# Altium schematic sheet 340-660-005.SchDoc(340-660-005)
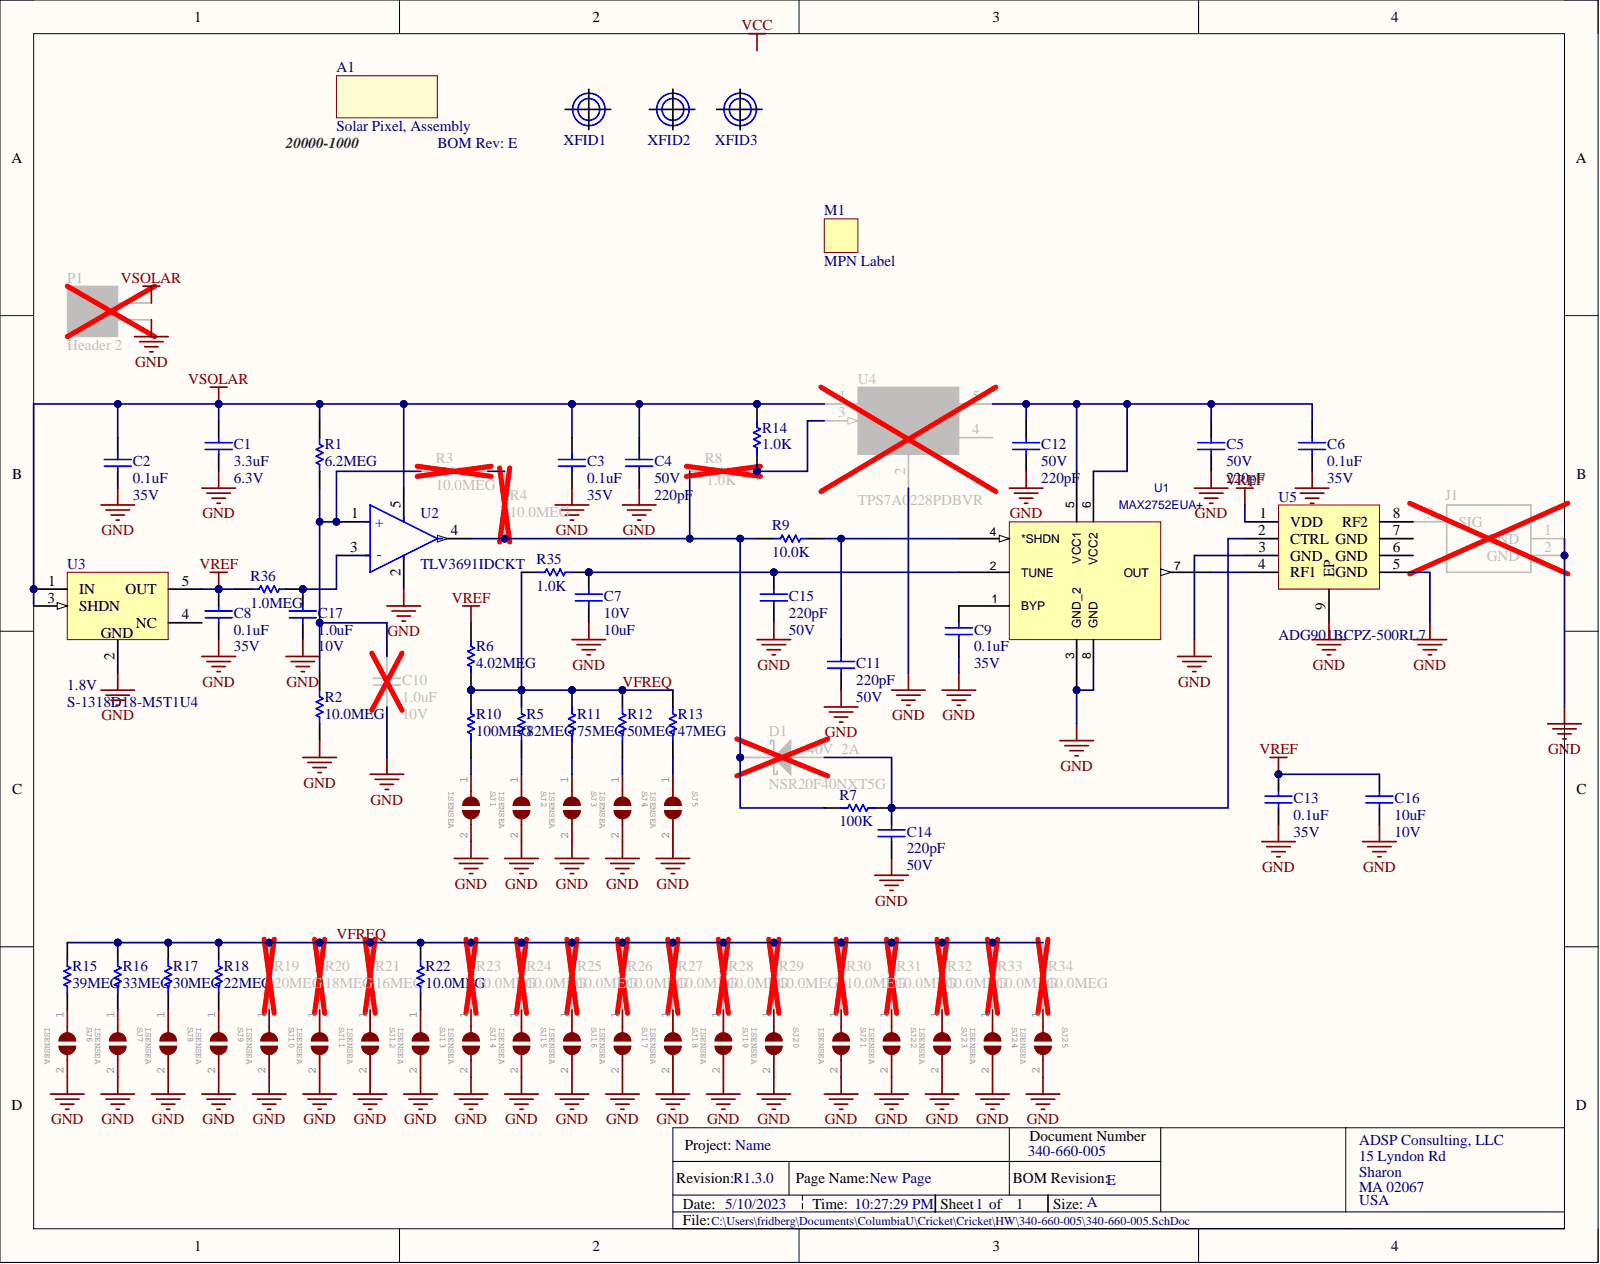
[diagram p0: 340-660-005.SchDoc]

## Parts
A1  Solar Pixel, Assembly  ADSP_PN: 20000-1000  (mechanical)
C1  value 3.3uF  Voltage: 6.3V  [0402CAP]  (hidden comment: C1005X5R0J335K050BC)
C2  value 0.1uF  Voltage: 35V  [0402CAP]  (hidden comment: GMK105BJ104KV-F)
C3  value 0.1uF  Voltage: 35V  [0402CAP]  (hidden comment: GMK105BJ104KV-F)
C4  value 220pF  Voltage: 50V  [0402CAP]  (hidden comment: ECJ-0EC1H221J)
C5  value 220pF  Voltage: 50V  [0402CAP]  (hidden comment: ECJ-0EC1H221J)
C6  value 0.1uF  Voltage: 35V  [0402CAP]  (hidden comment: GMK105BJ104KV-F)
C7  value 10uF  Voltage: 10V  [0402CAP]  (hidden comment: CL05A106MP5NUNC)
C8  value 0.1uF  Voltage: 35V  [0402CAP]  (hidden comment: GMK105BJ104KV-F)
C9  value 0.1uF  Voltage: 35V  [0402CAP]  (hidden comment: GMK105BJ104KV-F)
C10  value 1.0uF  Voltage: 10V  [0402CAP]  (hidden comment: 0402ZD105KAT2A)
C11  value 220pF  Voltage: 50V  [0402CAP]  (hidden comment: ECJ-0EC1H221J)
C12  value 220pF  Voltage: 50V  [0402CAP]  (hidden comment: ECJ-0EC1H221J)
C13  value 0.1uF  Voltage: 35V  [0402CAP]  (hidden comment: GMK105BJ104KV-F)
C14  value 220pF  Voltage: 50V  [0402CAP]  (hidden comment: ECJ-0EC1H221J)
C15  value 220pF  Voltage: 50V  [0402CAP]  (hidden comment: ECJ-0EC1H221J)
C16  value 10uF  Voltage: 10V  [0402CAP]  (hidden comment: CL05A106MP5NUNC)
C17  value 1.0uF  Voltage: 10V  [0402CAP]  (hidden comment: 0402ZD105KAT2A)
D1  NSR20F40NXT5G  Current: 2A  Voltage: 40V  [DIODE_2-DSN_ON]
J1  (no value)  [CONN_HRS-U.FL-R-SMT_V]
M1  MPN Label  [LABEL_7x7mm]  (no BOM)
P1  Header 2  [HDR1X2]
R1  6.2MEG  [0402RES]
R2  value 10.0MEG  [0402RES]  (hidden comment: CRCW040210M0FKED)
R3  value 10.0MEG  [0402RES]  (hidden comment: CRCW040210M0FKED)
R4  value 10.0MEG  [0402RES]  (hidden comment: CRCW040210M0FKED)
R5  value 82MEG  [0402RES]  (hidden comment: HMC0402JT82M0)
R6  4.02MEG  [0402RES]
R7  value 100K  [0402RES]  (hidden comment: RC0402FR-07100KL)
R8  value 1.0K  [0402RES]  (hidden comment: RCS04021K00FKED)
R9  value 10.0K  [0402RES]  (hidden comment: CRCW040210K0FKEDHP)
R10  value 100MEG  [0402RES]  (hidden comment: HMC0402JT100M)
R11  value 75MEG  [0402RES]  (hidden comment: HMC0402JT75M0)
R12  value 50MEG  [0402RES]  (hidden comment: HMC0402JT50M0)
R13  value 47MEG  [0402RES]  (hidden comment: HMC0402JT47M0)
R14  value 1.0K  [0402RES]  (hidden comment: RCS04021K00FKED)
R15  value 39MEG  [0402RES]  (hidden comment: HMC0402JT39M0)
R16  value 33MEG  [0402RES]  (hidden comment: HMC0402JT33M0)
R17  value 30MEG  [0402RES]  (hidden comment: HMC0402JT30M0)
R18  value 22MEG  [0402RES]  (hidden comment: RC0402JR-0722ML)
R19  value 20MEG  [0402RES]
R20  value 18MEG  [0402RES]
R21  value 16MEG  [0402RES]
R22  value 10.0MEG  [0402RES]  (hidden comment: CRCW040210M0FKED)
R23  value 10.0MEG  [0402RES]  (hidden comment: CRCW040210M0FKED)
R24  value 10.0MEG  [0402RES]  (hidden comment: CRCW040210M0FKED)
R25  value 10.0MEG  [0402RES]  (hidden comment: CRCW040210M0FKED)
R26  value 10.0MEG  [0402RES]  (hidden comment: CRCW040210M0FKED)
R27  value 10.0MEG  [0402RES]  (hidden comment: CRCW040210M0FKED)
R28  value 10.0MEG  [0402RES]  (hidden comment: CRCW040210M0FKED)
R29  value 10.0MEG  [0402RES]  (hidden comment: CRCW040210M0FKED)
R30  value 10.0MEG  [0402RES]  (hidden comment: CRCW040210M0FKED)
R31  value 10.0MEG  [0402RES]  (hidden comment: CRCW040210M0FKED)
R32  value 10.0MEG  [0402RES]  (hidden comment: CRCW040210M0FKED)
R33  value 10.0MEG  [0402RES]  (hidden comment: CRCW040210M0FKED)
R34  value 10.0MEG  [0402RES]  (hidden comment: CRCW040210M0FKED)
R35  value 1.0K  [0402RES]  (hidden comment: RCS04021K00FKED)
R36  value 1.0MEG  [0402RES]  (hidden comment: RC0402FR-071ML)
SJ1  ISENSEA  [SOLDERJUMPER_ARROW_NOPASTE]  (no BOM)
SJ2  ISENSEA  [SOLDERJUMPER_ARROW_NOPASTE]  (no BOM)
SJ3  ISENSEA  [SOLDERJUMPER_ARROW_NOPASTE]  (no BOM)
SJ4  ISENSEA  [SOLDERJUMPER_ARROW_NOPASTE]  (no BOM)
SJ5  ISENSEA  [SOLDERJUMPER_ARROW_NOPASTE]  (no BOM)
SJ6  ISENSEA  [SOLDERJUMPER_ARROW_NOPASTE]  (no BOM)
SJ7  ISENSEA  [SOLDERJUMPER_ARROW_NOPASTE]  (no BOM)
SJ8  ISENSEA  [SOLDERJUMPER_ARROW_NOPASTE]  (no BOM)
SJ9  ISENSEA  [SOLDERJUMPER_ARROW_NOPASTE]  (no BOM)
SJ10  ISENSEA  [SOLDERJUMPER_ARROW_NOPASTE]  (no BOM)
SJ11  ISENSEA  [SOLDERJUMPER_ARROW_NOPASTE]  (no BOM)
SJ12  ISENSEA  [SOLDERJUMPER_ARROW_NOPASTE]  (no BOM)
SJ13  ISENSEA  [SOLDERJUMPER_ARROW_NOPASTE]  (no BOM)
SJ14  ISENSEA  [SOLDERJUMPER_ARROW_NOPASTE]  (no BOM)
SJ15  ISENSEA  [SOLDERJUMPER_ARROW_NOPASTE]  (no BOM)
SJ16  ISENSEA  [SOLDERJUMPER_ARROW_NOPASTE]  (no BOM)
SJ17  ISENSEA  [SOLDERJUMPER_ARROW_NOPASTE]  (no BOM)
SJ18  ISENSEA  [SOLDERJUMPER_ARROW_NOPASTE]  (no BOM)
SJ19  ISENSEA  [SOLDERJUMPER_ARROW_NOPASTE]  (no BOM)
SJ20  ISENSEA  [SOLDERJUMPER_ARROW_NOPASTE]  (no BOM)
SJ21  ISENSEA  [SOLDERJUMPER_ARROW_NOPASTE]  (no BOM)
SJ22  ISENSEA  [SOLDERJUMPER_ARROW_NOPASTE]  (no BOM)
SJ23  ISENSEA  [SOLDERJUMPER_ARROW_NOPASTE]  (no BOM)
SJ24  ISENSEA  [SOLDERJUMPER_ARROW_NOPASTE]  (no BOM)
SJ25  ISENSEA  [SOLDERJUMPER_ARROW_NOPASTE]  (no BOM)
U1  MAX2752EUA+  [21-0036K_MXM]
U2  TLV3691IDCKT  [SC70/5]
U3  S-1318D18-M5T1U4  Vout: 1.8V  [SOT-23/5]
U4  TPS7A0228PDBVR  [SOT-23/5]
U5  ADG901BCPZ-500RL7  [SON50P300X300X85-9N-D]
XFID1  (no value)  [FID_TOP_BOT_39]
XFID2  (no value)  [FID_TOP_BOT_39]
XFID3  (no value)  [FID_TOP_BOT_39]

## Nets
GND: C1.1 C2.1 C3.1 C4.1 C5.1 C6.1 C7.1 C8.1 C9.1 C10.1 C11.1 C12.1 C13.1 C14.1 C15.1 C16.1 C17.1 J1.1 J1.2 P1.1 R2.1 SJ1.2 SJ2.2 SJ3.2 SJ4.2 SJ5.2 SJ6.2 SJ7.2 SJ8.2 SJ9.2 SJ10.2 SJ11.2 SJ12.2 SJ13.2 SJ14.2 SJ15.2 SJ16.2 SJ17.2 SJ18.2 SJ19.2 SJ20.2 SJ21.2 SJ22.2 SJ23.2 SJ24.2 SJ25.2 U1.3(GND_2) U1.8(GND) U2.2 U3.2 U4.2 U5.3(GND) U5.5(GND) U5.9(EP)
VREF: C8.2 C13.2 C16.2 R6.2 R36.2 U3.5(OUT) U5.1(VDD)
VSOLAR: C1.2 C2.2 C3.2 C4.2 P1.2 R1.2 R14.1 U2.5 U3.1(IN) U3.3(SHDN) U4.1(IN)
VFREQ: R5.2 R6.1 R10.2 R11.2 R12.2 R13.2 R15.2 R16.2 R17.2 R18.2 R19.2 R20.2 R21.2 R22.2 R23.2 R24.2 R25.2 R26.2 R27.2 R28.2 R29.2 R30.2 R31.2 R32.2 R33.2 R34.2 R35.2
NetC5_2: C5.2 C6.2 C12.2 U1.5(VCC1) U1.6(VCC2) U4.5(OUT)
NetC7_2: C7.2 C15.2 R35.1 U1.2(TUNE)
NetC9_2: C9.2 U1.1(BYP)
NetC10_2: C10.2 R1.1 R2.2 R3.2 U2.1(+)
NetC11_2: C11.2 R9.1 U1.4(*SHDN)
NetC14_2: C14.2 D1.A R7.1 U5.2(CTRL)
NetC17_2: C17.2 R36.1 U2.3(-)
NetD1_C: D1.C R4.1 R7.2 R8.2 R9.2 U2.4
NetJ1_3: J1.3 U5.8(RF2)
NetR3_1: R3.1 R4.2
NetR5_1: R5.1 SJ2.1
NetR8_1: R8.1 R14.2 U4.3(EN)
NetR10_1: R10.1 SJ1.1
NetR11_1: R11.1 SJ3.1
NetR12_1: R12.1 SJ4.1
NetR13_1: R13.1 SJ5.1
NetR15_1: R15.1 SJ6.1
NetR16_1: R16.1 SJ7.1
NetR17_1: R17.1 SJ8.1
NetR18_1: R18.1 SJ9.1
NetR19_1: R19.1 SJ10.1
NetR20_1: R20.1 SJ11.1
NetR21_1: R21.1 SJ12.1
NetR22_1: R22.1 SJ13.1
NetR23_1: R23.1 SJ14.1
NetR24_1: R24.1 SJ15.1
NetR25_1: R25.1 SJ16.1
NetR26_1: R26.1 SJ17.1
NetR27_1: R27.1 SJ18.1
NetR28_1: R28.1 SJ19.1
NetR29_1: R29.1 SJ20.1
NetR30_1: R30.1 SJ21.1
NetR31_1: R31.1 SJ22.1
NetR32_1: R32.1 SJ23.1
NetR33_1: R33.1 SJ24.1
NetR34_1: R34.1 SJ25.1
NetU1_7: U1.7(OUT) U5.4(RF1)
VCC:

Not connected: U3 4(NC); U4 4(NC); U5 6(GND) 7(GND)

## Library data of the parts
A1 = PCB_Assembly: BOM Rev: E; DocNum: 340-660-005; Description: Solar Pixel, Assembly; Library Name: AltiumSchLib.SchLib; Part: 20000-1000; Rev: R1.3.0; Type: ASSEMBLY
C1 = CAP_0402_10uF_X5R_10V: CAP CER 10UF 10V X5R 0402; ADSP_PN: 01020-167; Mfg: TDK; Type: CAPACITOR; Component Kind: Standard; ComponentLink1Description: Spec; ComponentLink2Description: Datasheet; Library Name: AltiumSchLib.SchLib; Mfg_PN: C1005X5R0J335K050BC; Part: C1005X5R0J335K050BC; Signal Integrity: C0402; Simulation: CAP; Publisher: ADSP Consulting, LLC
C2 C3 C6 C8 C9 C13 = CAP_0402_0.1uF_X5R_35V: CAP CER .10UF 35V X5R 0402; ADSP_PN: 01010-054; Mfg: Taiyo Yuden; Type: CAPACITOR; Component Kind: Standard; ComponentLink1Description: Manufacturer Link; ComponentLink1URL: http://www.yuden.com; ComponentLink2Description: Datasheet; ComponentLink2URL: http://www.yuden.co.jp/ut/product/pdf/dlmk_e.pdf; Library Name: AltiumSchLib.SchLib; Mfg_PN: GMK105BJ104KV-F; Part: GMK105BJ104KV-F; Signal Integrity: C0402; Simulation: CAP; Supplier 1: Digi-Key; Supplier 2: Digi-Key; Supplier Part Number 1: 587-1994-1-ND; Supplier Part Number 2: 587-1994-6-ND; Publisher: ADSP Consulting, LLC
C4 C5 C11 C12 C14 C15 = CAP_0402_220pF_NP0_50V: CAP 220PF 50V CERAMIC 0402 SMD; ADSP_PN: 01010-028; Mfg: Panasonic; Type: CAPACITOR; Component Kind: Standard; ComponentLink1Description: Manufacturer Link; ComponentLink1URL: http://industrial.panasonic.com; ComponentLink2Description: Datasheet; ComponentLink2URL: http://industrial.panasonic.com/www-data/pdf/ABJ0000/ABJ0000CE1.pdf; Library Name: AltiumSchLib.SchLib; Mfg_PN: ECJ-0EC1H221J; Part: ECJ-0EC1H221J; Signal Integrity: C0402; Simulation: CAP; Supplier 1: Digi-Key; Supplier 2: Digi-Key; Supplier Part Number 1: PCC221CQCT-ND; Supplier Part Number 2: PCC221CQTR-ND; Publisher: ADSP Consulting, LLC
C7 C16 = CAP_0402_10uF_X5R_10V: CAP CER 10UF 10V X5R 0402; ADSP_PN: 01020-053; Mfg: Samsung; Type: CAPACITOR; Component Kind: Standard; ComponentLink1Description: Spec; ComponentLink1URL: http://www.samsungsem.com/kr/support/product-search/mlcc/CL05A106MP5NUNC.jsp; ComponentLink2Description: Datasheet; ComponentLink2URL: https://media.digikey.com/pdf/Data%20Sheets/Samsung%20PDFs/CL_Series_MLCC_ds.pdf; HelpURL: https://www.digikey.com/product-detail/en/samsung-electro-mechanics/CL05A106MP5NUNC/1276-1450-1-ND/3889536; Library Name: AltiumSchLib.SchLib; Mfg_PN: CL05A106MP5NUNC; Part: CL05A106MP5NUNC; Signal Integrity: C0402; Simulation: CAP; Supplier 1: Newark; Supplier 2: Arrow; Supplier Part Number 1: 82AC9190; Supplier Part Number 2: CL05A106MP5NUNC; Publisher: ADSP Consulting, LLC
C10 C17 = CAP_0402_1uF_X5R_10V: CAP CERAMIC 1UF 10V X5R 0402; ADSP_PN: 01020-057; Mfg: AVX; Type: CAPACITOR; Component Kind: Standard; ComponentLink1Description: Manufacturer Link; ComponentLink1URL: http://www.murata.co.jp; ComponentLink2Description: Datasheet; ComponentLink2URL: http://search.murata.co.jp/Ceramy/image/img/PDF/ENG/GRM155R61A105ME15.pdf; Library Name: AltiumSchLib.SchLib; Mfg_PN: 0402ZD105KAT2A; Part: 0402ZD105KAT2A; Signal Integrity: C0402; Simulation: CAP; Supplier 1: Octopart; Supplier 2: Octopart; Supplier 3: Arrow; Supplier Part Number 1: Digi-Key - 490-5409-1-ND; Supplier Part Number 2: Mouser - 81-GRM155R61A105ME5D; Supplier Part Number 3: 0uyQcnB1MzVTno5V05qqdMHdKEPD0ulzJqQipA1FZ_7L41RCS0E1VAx706hUl3Jd; Publisher: ADSP Consulting, LLC
D1 = Diode_SHOTTKY_NSR20F40NXT5G: Diode Schottky 40V 2A (DC) Surface Mount 2-DSN (1.6x.80); ADSP_PN: 08100-027; Mfg: ON Semi; Component Kind: Standard; ComponentLink1Description: Manufacturer Link; ComponentLink1URL: https://www.onsemi.com/PowerSolutions/product.do?id=NSR20F40NXT5G; ComponentLink2Description: Datasheet; ComponentLink2URL: https://www.onsemi.com/pub/Collateral/NSR20F40-D.PDF; Library Name: AltiumSchLib.SchLib; Mfg_PN: NSR20F40NXT5G; Part: NSR20F40NXT5G; Supplier 1: Digi-Key; Supplier 2: Mouser; Supplier Part Number 1: NSR20F40NXT5GOSCT-ND; Supplier Part Number 2: 863-NSR20F40NXT5G; Type: DIODE_SHOTTKY
J1 = CONN_Hirose_U.FL-R-SMT(10): Ultra Small Surface Mount Coaxial Connector, 50 Ohm, -40 to 90 degC, 3-Pin SMD, RoHS, Tape and Reel; ADSP_PN: 03000-233; Current Rating: 3 A; Mfg: Hirose; Colour: Black; Component Kind: Standard; ComponentLink1Description: Manufacturer URL; ComponentLink1URL: https://www.hirose.com; ComponentLink2Description: Datasheet; ComponentLink2URL: https://www.hirose.com/product/en/download_file/key_name/U.FL/category/Catalog/doc_file_id/31697/?file_category_id=4&item_id=15&is_series=1; Contact Finish: Lead; Contact Material: Bronze; Contact Plating: Tin; Height: 8.13 mm; Lead Pitch: 2.54 mm; Length: 101.19 mm; Library Name: AltiumSchLib.SchLib; Max Operating Temperature: 105degC; Mfg_PN: U.FL-R-SMT(10); Min Operating Temperature: -65degC; Package Quantity: 50; PackageDescription: 3-Pin Surface Mount Device, Body 2.6 x 2.6 mm; Part: U.FL-R-SMT(10); Supplier 1: Digi-Key; Supplier 2: Future Electronics; Supplier Part Number 1: H122041-ND; Supplier Part Number 2: W.FL-R-SMT-1(10); Width: 4.88 mm
M1 = Label_MPN: Serial Number Label Placeholder; Library Name: AltiumSchLib.SchLib; Part: MPN Label
P1 = Header 2: Header, 2-Pin; Component Kind: Standard; LatestRevisionDate: 17-Jul-2002; LatestRevisionNote: Re-released for DXP Platform.; Library Name: Miscellaneous Connectors.IntLib; Signal Integrity: Connector; Publisher: Altium Limited
R1 = Res_0402_6.2MEG_1%: RES 6.2M OHM 1/16W 1% 0402 SMD; ADSP_PN: 00100-134; Mfg: Vishay; Mfg_PN: CRCW04026M20JNED; Component Kind: Standard; ComponentLink1Description: Manufacturer Link; ComponentLink1URL: http://www.vishay.com; ComponentLink2Description: Datasheet; ComponentLink2URL: http://www.vishay.com/docs/20035/dcrcwe3.pdf; Library Name: AltiumSchLib.SchLib; Part: 6.2MEG; Signal Integrity: Res; Simulation: RESISTOR; Supplier 1: Digi-Key; Supplier 2: Mouser; Supplier Part Number 1: 541-6.2MJCT-ND; Supplier Part Number 2: 71-CRCW04026M20JNED; Type: RESISTOR; Value: 6.2MEG; Publisher: ADSP Consulting, LLC
R2-R4 R19 R22-R34 = Res_0402_10MEG_1%: RES 10M OHM 1/16W 1% 0402 SMD; ADSP_PN: 00100-135; Mfg: Vishay; Component Kind: Standard; ComponentLink1Description: Manufacturer Link; ComponentLink1URL: http://www.vishay.com; ComponentLink2Description: Datasheet; ComponentLink2URL: http://www.vishay.com/docs/20035/dcrcwe3.pdf; Library Name: AltiumSchLib.SchLib; Signal Integrity: Res; Simulation: RESISTOR; Type: RESISTOR; Publisher: ADSP Consulting, LLC
  R2-R4 R22-R34: Mfg_PN: CRCW040210M0FKED; Part: CRCW040210M0FKED; Supplier 1: Digi-Key; Supplier 2: Mouser; Supplier Part Number 1: 541-10.0MLDKR-ND; Supplier Part Number 2: 71-CRCW040210M0FKED
R5 = Res_0402_10MEG_1%: RES 10M OHM 1/16W 1% 0402 SMD; ADSP_PN: 00100-228; Mfg: Stackpole; Component Kind: Standard; ComponentLink1Description: Manufacturer Link; ComponentLink2Description: Datasheet; Library Name: AltiumSchLib.SchLib; Mfg_PN: HMC0402JT82M0; Part: HMC0402JT82M0; Signal Integrity: Res; Simulation: RESISTOR; Type: RESISTOR; Publisher: ADSP Consulting, LLC
R6 = Res_0402_4.02MEG_1%: RES 4.02M OHM 1/16W 1% 0402 SMD; ADSP_PN: 00100-132; Mfg: Vishay; Mfg_PN: CRCW04024M02FKED; Component Kind: Standard; ComponentLink1Description: Manufacturer Link; ComponentLink1URL: http://www.vishay.com; ComponentLink2Description: Datasheet; ComponentLink2URL: http://www.vishay.com/docs/20035/dcrcwe3.pdf; Library Name: AltiumSchLib.SchLib; Part: 4.02MEG; Signal Integrity: Res; Simulation: RESISTOR; Supplier 1: Digi-Key; Supplier 2: Mouser; Supplier Part Number 1: 541-4.02MLCT-ND; Supplier Part Number 2: 71-CRCW04024M02FKED; Type: RESISTOR; Value: 4.02MEG; Publisher: ADSP Consulting, LLC
R7 = Res_0402_100K_1%: RES 100K OHM 1/16W 1% 0402 SMD; ADSP_PN: 00100-028; Mfg: Yageo; Component Kind: Standard; ComponentLink1Description: Manufacturer Link; ComponentLink1URL: http://www.yageo.com; ComponentLink2Description: Datasheet; ComponentLink2URL: http://www.yageo.com/pdf/Pu-RC0402_51_PbFree_L_2.pdf; Library Name: AltiumSchLib.SchLib; Mfg_PN: RC0402FR-07100KL; Part: RC0402FR-07100KL; Signal Integrity: Res; Simulation: RESISTOR; Supplier 1: Digi-Key; Supplier 2: Newark; Supplier 3: Digi-Key; Supplier 4: Newark; Supplier Part Number 1: 311-100KLRCT-ND; Supplier Part Number 2: 98K7502; Supplier Part Number 3: 311-100KLRTR-ND; Supplier Part Number 4: 98K7501; Type: RESISTOR; Publisher: ADSP Consulting, LLC
R8 R14 R35 = Res_0402_1.0K_1%: RES SMD 1K OHM 1% 1/5W 0402; ADSP_PN: 00100-158; Mfg: Panasonic; Component Kind: Standard; ComponentLink1Description: Manufacturer Link; ComponentLink1URL: http://industrial.panasonic.com/www-cgi/jvcr13pz.cgi?E+PZ+3+AOA0002+ERJ2RKF1001X+7+WW; ComponentLink2Description: Datasheet; ComponentLink2URL: http://industrial.panasonic.com/www-data/pdf/AOA0000/AOA0000CE2.pdf; Library Name: AltiumSchLib.SchLib; Mfg_PN: RCS04021K00FKED; Part: RCS04021K00FKED; Signal Integrity: Res; Simulation: RESISTOR; Supplier 1: Digi-Key; Supplier Part Number 1: 541-2888-1-ND; Type: RESISTOR; Publisher: ADSP Consulting, LLC
R9 = Res_0402_10.0K_1%: RES 10K OHM 1/10W 1% 0402 SMD; ADSP_PN: 00100-161; Mfg: Vishay; Component Kind: Standard; ComponentLink1Description: Manufacturer Link; ComponentLink1URL: http://www.vishay.com; ComponentLink2Description: Datasheet; ComponentLink2URL: http://www.vishay.com/doc?20035; Library Name: AltiumSchLib.SchLib; Mfg_PN: CRCW040210K0FKEDHP; Part: CRCW040210K0FKEDHP; Signal Integrity: Res; Simulation: RESISTOR; Supplier 1: Avnet; Supplier 2: Mouser; Supplier 3: Digi-Key; Supplier Part Number 1: CRCW040210K0FKEDHP/BKN; Supplier Part Number 2: 71-CRCW040210K0FKEDH; Supplier Part Number 3: 541-10.0KYDKR-ND; Type: RESISTOR; Publisher: ADSP Consulting, LLC
R10 = Res_0402_10MEG_1%: RES 10M OHM 1/16W 1% 0402 SMD; ADSP_PN: 00100-226; Mfg: Stackpole; Component Kind: Standard; ComponentLink1Description: Manufacturer Link; ComponentLink2Description: Datasheet; Library Name: AltiumSchLib.SchLib; Mfg_PN: HMC0402JT100M; Part: HMC0402JT100M; Signal Integrity: Res; Simulation: RESISTOR; Type: RESISTOR; Publisher: ADSP Consulting, LLC
R11 = Res_0402_10MEG_1%: RES 10M OHM 1/16W 1% 0402 SMD; ADSP_PN: 00100-232; Mfg: Stackpole; Component Kind: Standard; ComponentLink1Description: Manufacturer Link; ComponentLink2Description: Datasheet; Library Name: AltiumSchLib.SchLib; Mfg_PN: HMC0402JT75M0; Part: HMC0402JT75M0; Signal Integrity: Res; Simulation: RESISTOR; Type: RESISTOR; Publisher: ADSP Consulting, LLC
R12 = Res_0402_10MEG_1%: RES 10M OHM 1/16W 1% 0402 SMD; ADSP_PN: 00100-231; Mfg: Stackpole; Component Kind: Standard; ComponentLink1Description: Manufacturer Link; ComponentLink1URL: http://www.vishay.com; ComponentLink2Description: Datasheet; ComponentLink2URL: http://www.vishay.com/docs/20035/dcrcwe3.pdf; Library Name: AltiumSchLib.SchLib; Mfg_PN: HMC0402JT50M0; Part: HMC0402JT50M0; Signal Integrity: Res; Simulation: RESISTOR; Type: RESISTOR; Publisher: ADSP Consulting, LLC
R13 = Res_0402_10MEG_1%: RES 10M OHM 1/16W 1% 0402 SMD; ADSP_PN: 00100-227; Mfg: Stackpole; Component Kind: Standard; ComponentLink1Description: Manufacturer Link; ComponentLink2Description: Datasheet; Library Name: AltiumSchLib.SchLib; Mfg_PN: HMC0402JT47M0; Part: HMC0402JT47M0; Signal Integrity: Res; Simulation: RESISTOR; Type: RESISTOR; Publisher: ADSP Consulting, LLC
R15 = Res_0402_10MEG_1%: RES 10M OHM 1/16W 1% 0402 SMD; ADSP_PN: 00100-233; Mfg: Stackpole; Component Kind: Standard; ComponentLink1Description: Manufacturer Link; ComponentLink2Description: Datasheet; Library Name: AltiumSchLib.SchLib; Mfg_PN: HMC0402JT39M0; Part: HMC0402JT39M0; Signal Integrity: Res; Simulation: RESISTOR; Type: RESISTOR; Publisher: ADSP Consulting, LLC
R16 = Res_0402_10MEG_1%: RES 10M OHM 1/16W 1% 0402 SMD; ADSP_PN: 00100-230; Mfg: Stackpole; Component Kind: Standard; ComponentLink1Description: Manufacturer Link; ComponentLink2Description: Datasheet; Library Name: AltiumSchLib.SchLib; Mfg_PN: HMC0402JT33M0; Part: HMC0402JT33M0; Signal Integrity: Res; Simulation: RESISTOR; Type: RESISTOR; Publisher: ADSP Consulting, LLC
R17 = Res_0402_10MEG_1%: RES 10M OHM 1/16W 1% 0402 SMD; ADSP_PN: 00100-234; Mfg: Stackpole; Component Kind: Standard; ComponentLink1Description: Manufacturer Link; ComponentLink2Description: Datasheet; Library Name: AltiumSchLib.SchLib; Mfg_PN: HMC0402JT30M0; Part: HMC0402JT30M0; Signal Integrity: Res; Simulation: RESISTOR; Type: RESISTOR; Publisher: ADSP Consulting, LLC
R18 = Res_0402_10MEG_1%: RES 10M OHM 1/16W 1% 0402 SMD; ADSP_PN: 00100-229; Mfg: Yageo; Component Kind: Standard; ComponentLink1Description: Manufacturer Link; ComponentLink2Description: Datasheet; Library Name: AltiumSchLib.SchLib; Mfg_PN: RC0402JR-0722ML; Part: RC0402JR-0722ML; Signal Integrity: Res; Simulation: RESISTOR; Type: RESISTOR; Publisher: ADSP Consulting, LLC
R20 = Res_0402_10MEG_1%: RES 10M OHM 1/16W 1% 0402 SMD; ADSP_PN: 00100-135; Component Kind: Standard; ComponentLink1Description: Manufacturer Link; ComponentLink2Description: Datasheet; Library Name: AltiumSchLib.SchLib; Signal Integrity: Res; Simulation: RESISTOR; Type: RESISTOR; Publisher: ADSP Consulting, LLC
R21 = Res_0402_10MEG_1%: RES 10M OHM 1/16W 1% 0402 SMD; ADSP_PN: 00100-; Component Kind: Standard; ComponentLink1Description: Manufacturer Link; ComponentLink2Description: Datasheet; Library Name: AltiumSchLib.SchLib; Signal Integrity: Res; Simulation: RESISTOR; Type: RESISTOR; Publisher: ADSP Consulting, LLC
R36 = Res_0402_1MEG_1%: RES 1.0M OHM 1/16W 5% 0402 SMD; ADSP_PN: 00100-157; Mfg: Yageo; Component Kind: Standard; ComponentLink1Description: Manufacturer Link; ComponentLink1URL: http://www.vishay.com; ComponentLink2Description: Datasheet; ComponentLink2URL: http://www.vishay.com/doc?20035; Library Name: AltiumSchLib.SchLib; Mfg_PN: RC0402FR-071ML; Part: RC0402FR-071ML; Signal Integrity: Res; Simulation: RESISTOR; Supplier 1: Digi-Key; Supplier 2: Newark; Supplier Part Number 1: 311-1.00MLRCT-ND; Supplier Part Number 2: 58K4211; Type: RESISTOR; Publisher: ADSP Consulting, LLC
SJ1-SJ25 = SOLDERJUMPER_OPEN: SMD Solder JUMPER   Solder the two pads together to create a connection, or remove the solder to break it.REFLOW - Use this footprint for solder paste and reflow ovens. WAVE - Use this footprint for hand-soldering (larger pads).    CLOSED - Has a trace between the two pads to ensure it is closed by default.  The trace needs to be cut to disable the jumper, and can be closed again by creating a solder bridge between the two pads.; BOM: EXCLUDE; GateName_1: 1; DeviceSetName: SOLDERJUMPER; Library Name: AltiumSchLib.SchLib; LibraryName: microbuilder; SymbolName_1: SOLDERJUMPER
U1 = MAX2752EUA+: RF IC VCO ISM 2.025GHz ~ 2.165GHz On-Chip Tank Circuit 8-uMAX/uSOP; ADSP_PN: 10030-015; Mfg: Maxim Integrated Products; Component Kind: Standard; Library Name: AltiumSchLib.SchLib; Mfg_PN: MAX2752EUA+; Part: MAX2752EUA+; Type: VCO; Copyright: Copyright (C) 2022 Ultra Librarian. All rights reserved.
U2 = TLV3691IDCKT: IC COMPARATOR NANO-POWER SC70-5; ADSP_PN: 07013-008; Delay: 35us; Iq: 150nA; Mfg: Texas Instrument; Vmax: 5.5V; Vmin: 0.9V; Component Kind: Standard; ComponentLink1Description: Datasheet; ComponentLink1URL: https://www.ti.com/general/docs/suppproductinfo.tsp?distId=10&gotoUrl=https%3A%2F%2Fwww.ti.com%2Flit%2Fgpn%2Ftlv3691; Library Name: AltiumSchLib.SchLib; Mfg_PN: TLV3691IDCKT; Part: TLV3691IDCKT; Supplier 1: Mouser; Supplier 2: Farnell; Supplier Part Number 1: 595-TLV3691IDCKT; Supplier Part Number 2: 3005614; Type: COMPARATOR
U3 = S-1318D18-M5T1U4: Ultra-Low Quescent Current Linear Voltage Regulator IC Positive Fixed 1.8V 100mA SOT-23-5; ADSP_PN: 07600-034; Mfg: ABLIC; Component Kind: Standard; ComponentLink1Description: Manufacturer Link; ComponentLink1URL: https://www.ablic.com/en/semicon/datasheets/power-management-ic/voltage-regulator-ldo/s-1318/; ComponentLink2Description: Datasheet; ComponentLink2URL: https://www.ablic.com/en/doc/datasheet/voltage_regulator/S1318_E.pdf; Library Name: AltiumSchLib.SchLib; Mfg_PN: S-1318D18-M5T1U4; PackageDescription: 5-Pin SOT-23 Package 0.95 mm Pitch, 2.8 mm Lead Span; Part: S-1318D18-M5T1U4; Supplier 1: Digi-Key; Supplier Part Number 1: 1662-S-1318D18-M5T1U4CT-ND; Type: LDO_POS; Publisher: ADSP Consulting, LLC
U4 = TPS7A0228PDBVR: 2.8V, 1-µA, ultra-low-IQ, 200-mA low-dropout (LDO) regulator in a small-sized; ADSP_PN: 07600-???; Mfg: Texas Instruments; Component Kind: Standard; ComponentLink1Description: Manufacturer Link; ComponentLink1URL: https://www.ti.com/product/TPS7A02/part-details/TPS7A0228PDBVR; ComponentLink2Description: Datasheet; ComponentLink2URL: https://www.ti.com/lit/ds/symlink/tps7a02.pdf; Library Name: AltiumSchLib.SchLib; Mfg_PN: TPS7A0228PDBVR; Part: TPS7A0228PDBVR; Simulation: TL431; Supplier 1: Digi-Key; Supplier 2: Mouser; Supplier Part Number 1: 296-TPS7A0228PDBVRCT-ND; Supplier Part Number 2: 595-TPS7A0228PDBVR; Type: LDO; Value: 2.8V; Publisher: ADSP Consulting, LLC
U5 = ADG901BCPZ: RF Switch IC General Purpose SPST 2.5 GHz 50Ohm 8-LFCSP (3x3); ADSP_PN: 07221-014; Mfg: CEL; Component Kind: Standard; ComponentLink1Description: Manufacturer Web Site; ComponentLink1URL: https://www.analog.com/en/products/adg901.html?doc=ADG901_902.pdf; ComponentLink2Description: Datasheet; ComponentLink2URL: https://www.analog.com/media/en/technical-documentation/data-sheets/ADG901_902.pdf; Library Name: AltiumSchLib.SchLib; Mfg_PN: ADG901BCPZ-500RL7; Part: ADG901BCPZ-500RL7; Supplier 1: Digi-Key; Supplier 2: Mouser; Supplier 3: Newark; Supplier 4: Arrow Electronics; Supplier Part Number 1: 505-ADG901BCPZ-500RL7CT-ND; Supplier Part Number 2: 584-ADG901BCPZ-500R7; Supplier Part Number 3: 51AK5773; Supplier Part Number 4: ADG901BCPZ-500RL7; Type: RF_SWITCH; Publisher: ADSP Consulting, LLC
XFID1-XFID3 = MOUNT_FIDUCIAL: Mounting Hole; Component Kind: Standard; Library Name: AltiumSchLib.SchLib; Type: MOUNT_FID
